# Altium schematic sheet [03] - MCU.SchDoc(MCU)
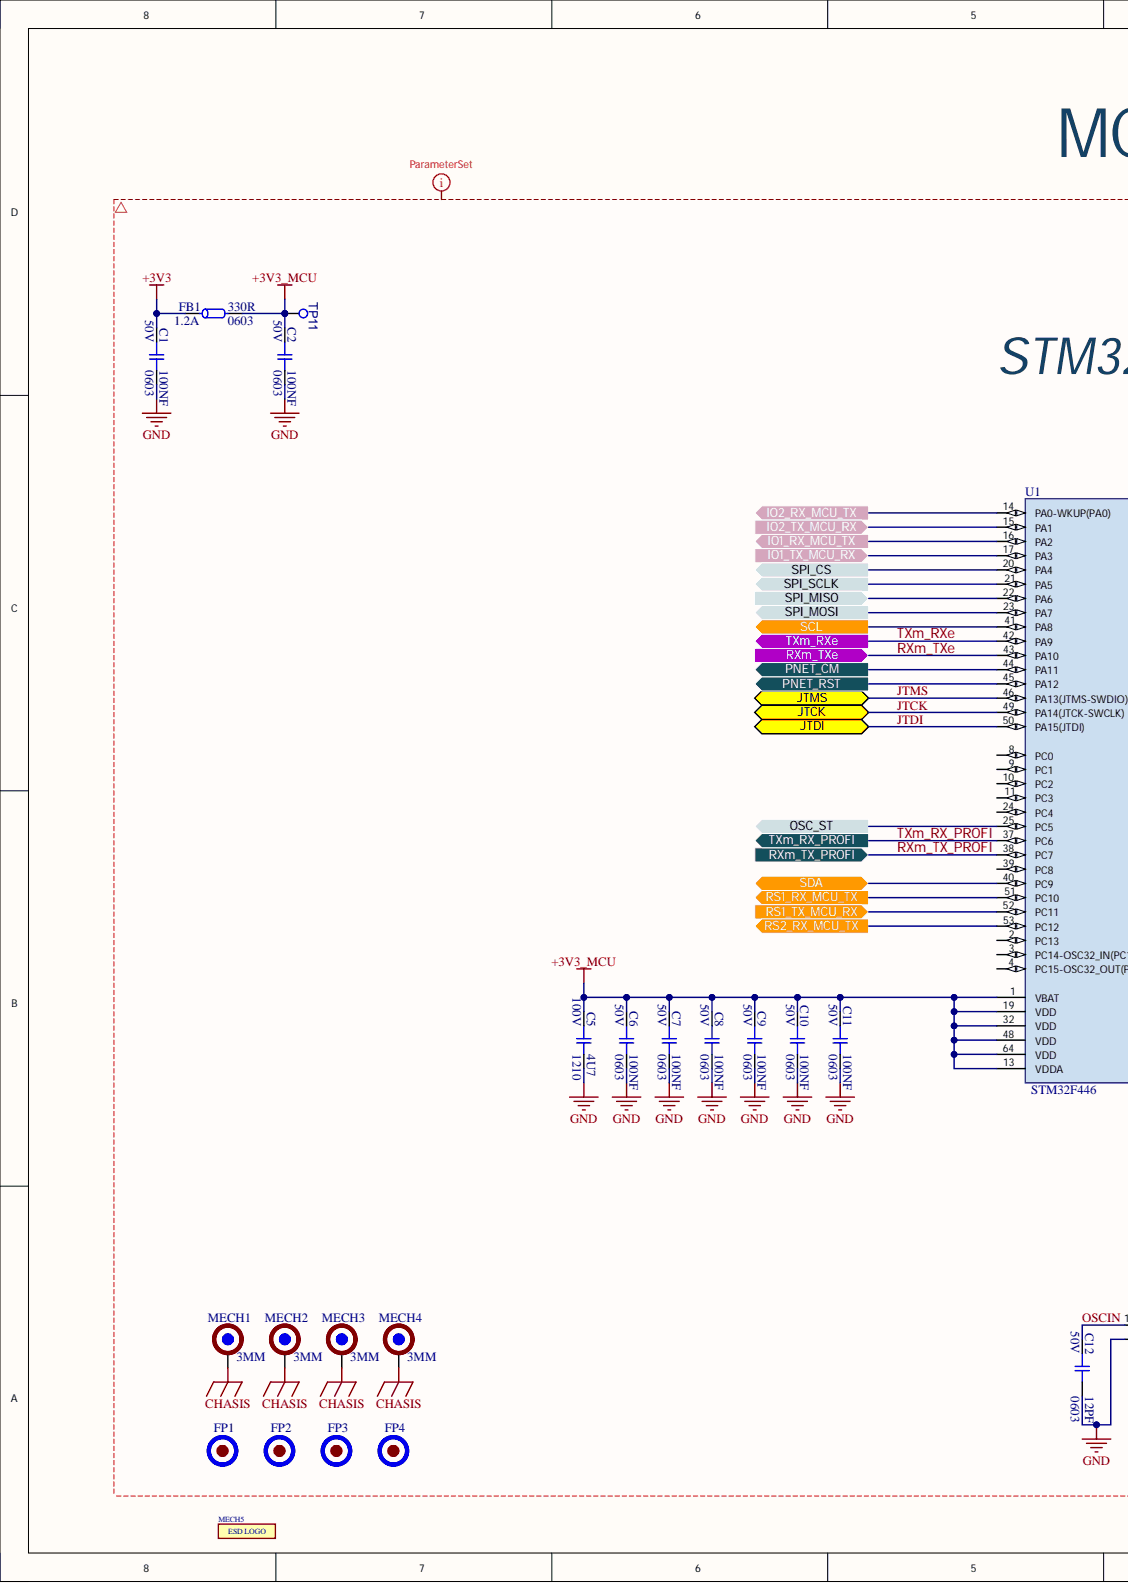
[diagram p0: [03] - MCU.SchDoc, left]
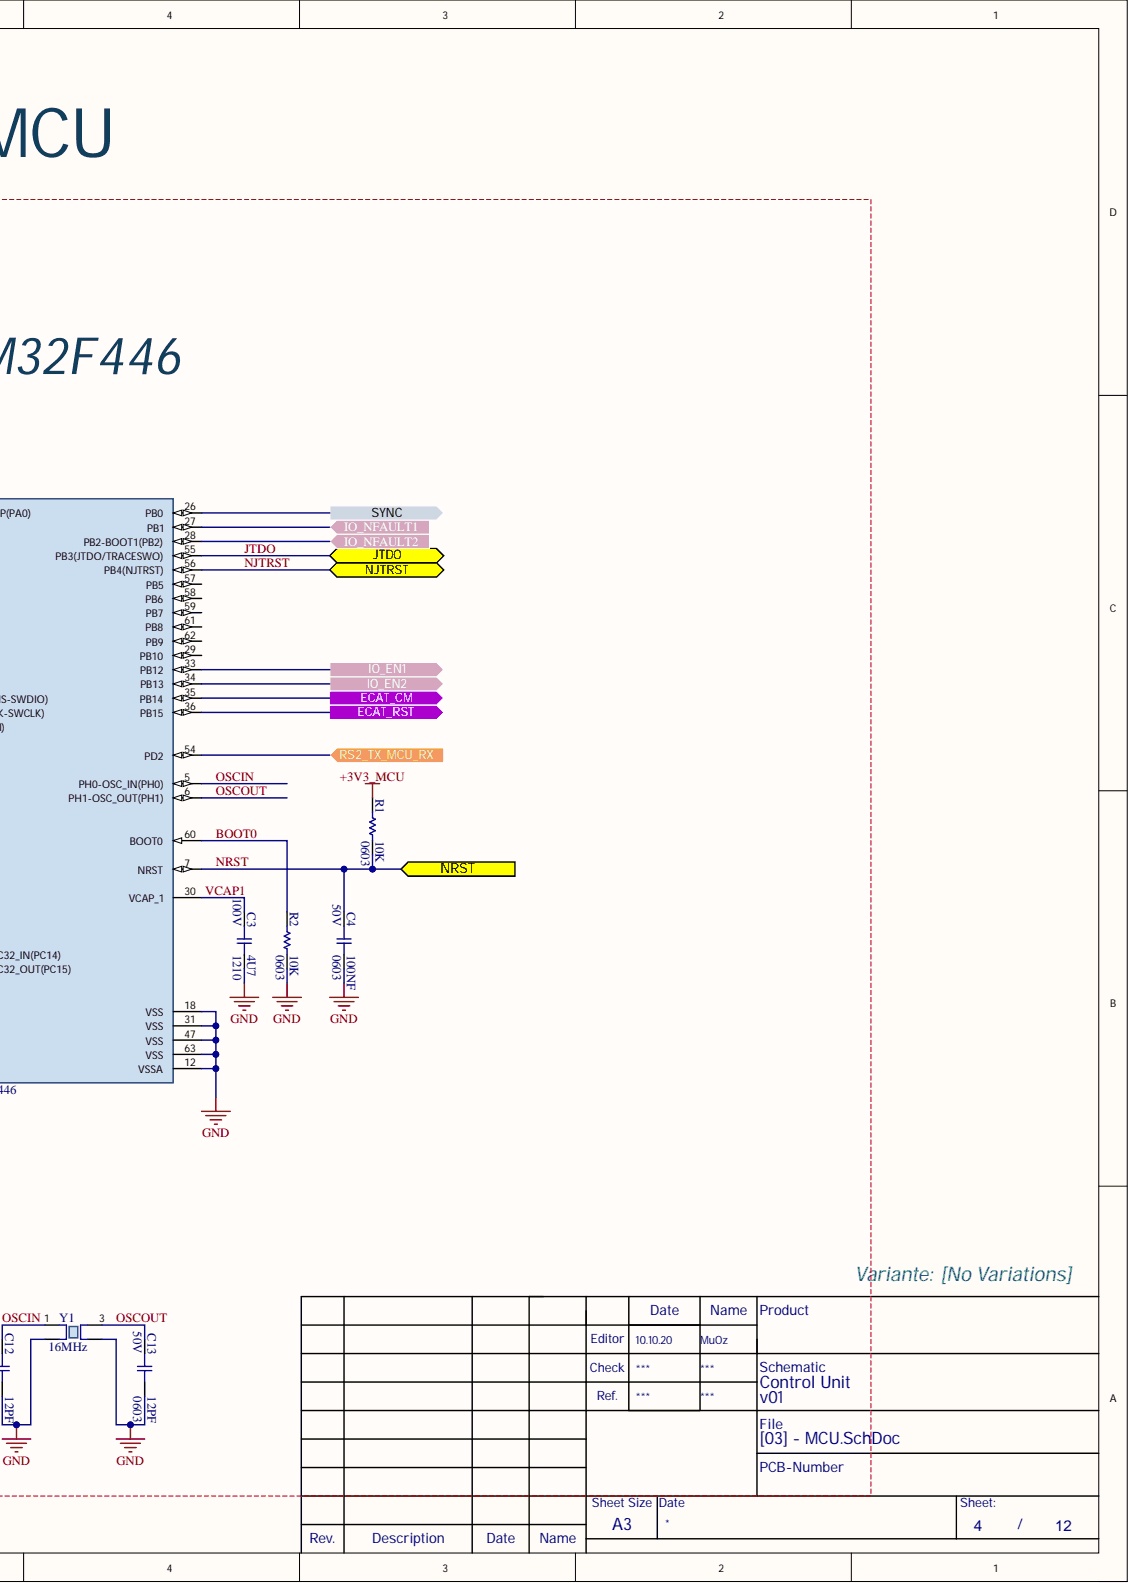
[diagram p1: [03] - MCU.SchDoc, right]

## Parts
C1  100NF  Case Code (Imperial): 0603  Voltage: 50V  [C-0603]
C2  100NF  Case Code (Imperial): 0603  Voltage: 50V  [C-0603]
C3  4U7  Case/Package: 1210  Voltage Rating: 100V  [C-1210]
C4  100NF  Case Code (Imperial): 0603  Voltage: 50V  [C-0603]
C5  4U7  Case/Package: 1210  Voltage Rating: 100V  [C-1210]
C6  100NF  Case Code (Imperial): 0603  Voltage: 50V  [C-0603]
C7  100NF  Case Code (Imperial): 0603  Voltage: 50V  [C-0603]
C8  100NF  Case Code (Imperial): 0603  Voltage: 50V  [C-0603]
C9  100NF  Case Code (Imperial): 0603  Voltage: 50V  [C-0603]
C10  100NF  Case Code (Imperial): 0603  Voltage: 50V  [C-0603]
C11  100NF  Case Code (Imperial): 0603  Voltage: 50V  [C-0603]
C12  12PF  Case Code (Imperial): 0603  Voltage: 50V  [C-0603]
C13  12PF  Case Code (Imperial): 0603  Voltage: 50V  [C-0603]
FB1  330R  Case Code (Imperial): 0603  Current Rating: 1.2A  [FB-0603]
FP1  MECH-Fiducial  [MECH-Fiducial]  (value not drawn)  (no BOM)
FP2  MECH-Fiducial  [MECH-Fiducial]  (value not drawn)  (no BOM)
FP3  MECH-Fiducial  [MECH-Fiducial]  (value not drawn)  (no BOM)
FP4  MECH-Fiducial  [MECH-Fiducial]  (value not drawn)  (no BOM)
MECH1  3MM  [MECH-3MM HOLE]  (no BOM)
MECH2  3MM  [MECH-3MM HOLE]  (no BOM)
MECH3  3MM  [MECH-3MM HOLE]  (no BOM)
MECH4  3MM  [MECH-3MM HOLE]  (no BOM)
MECH5  MECH-ESD-LOGO  [ESD_LOGO_4]  (value not drawn)  (no BOM)
R1  10K  Case Code (Imperial): 0603  [R-0603]
R2  10K  Case Code (Imperial): 0603  [R-0603]
TP11  MECH-TESTPOINT  [MECH-TESTPOINT]  (value not drawn)  (no BOM)
U1  STM32F446  [STM-LQFP64_M]
Y1  16MHz  [CRY-TSX-3225]

## Ports
ECAT_CM, ECAT_RST, IO1_RX_MCU_TX, IO1_TX_MCU_RX, IO2_RX_MCU_TX, IO2_TX_MCU_RX, IO_EN1, IO_EN2, IO_NFAULT1, IO_NFAULT2, JTCK, JTDI, JTDO, JTMS, NJTRST, NRST, OSC_ST, PNET_CM, PNET_RST, RS1_RX_MCU_TX, RS1_TX_MCU_RX, RS2_RX_MCU_TX, RS2_TX_MCU_RX, RXm_TX_PROFI, RXm_TXe, SCL, SDA, SPI_CS, SPI_MISO, SPI_MOSI, SPI_SCLK, SYNC, TXm_RX_PROFI, TXm_RXe

## Nets
+3V3: C1.1 FB1.1
+3V3_MCU: C2.1 C5.1 C6.1 C7.1 C8.1 C9.1 C10.1 C11.1 FB1.2 R1.1 TP11.1 U1.1(VBAT) U1.13(VDDA) U1.19(VDD) U1.32(VDD) U1.48(VDD) U1.64(VDD)
CHASIS: MECH1.1 MECH2.1 MECH3.1 MECH4.1
GND: C1.2 C2.2 C3.2 C4.2 C5.2 C6.2 C7.2 C8.2 C9.2 C10.2 C11.2 C12.2 C13.2 R2.2 U1.12(VSSA) U1.18(VSS) U1.31(VSS) U1.47(VSS) U1.63(VSS) Y1.2 Y1.4
BOOT0: R2.1 U1.60(BOOT0)
ECAT_CM: U1.35(PB14) ; port ECAT_CM
ECAT_RST: U1.36(PB15) ; port ECAT_RST
IO1_RX_MCU_TX: U1.16(PA2) ; port IO1_RX_MCU_TX
IO1_TX_MCU_RX: U1.17(PA3) ; port IO1_TX_MCU_RX
IO2_RX_MCU_TX: U1.14(PA0-WKUP(PA0)) ; port IO2_RX_MCU_TX
IO2_TX_MCU_RX: U1.15(PA1) ; port IO2_TX_MCU_RX
IO_EN1: U1.33(PB12) ; port IO_EN1
IO_EN2: U1.34(PB13) ; port IO_EN2
IO_NFAULT1: U1.27(PB1) ; port IO_NFAULT1
IO_NFAULT2: U1.28(PB2-BOOT1(PB2)) ; port IO_NFAULT2
JTCK: U1.49(PA14(JTCK-SWCLK)) ; port JTCK
JTDI: U1.50(PA15(JTDI)) ; port JTDI
JTDO: U1.55(PB3(JTDO/TRACESWO)) ; port JTDO
JTMS: U1.46(PA13(JTMS-SWDIO)) ; port JTMS
NJTRST: U1.56(PB4(NJTRST)) ; port NJTRST
NRST: C4.1 R1.2 U1.7(NRST) ; port NRST
OSC_ST: U1.25(PC5) ; port OSC_ST
OSCIN: C12.1 U1.5(PH0-OSC_IN(PH0)) Y1.1
OSCOUT: C13.1 U1.6(PH1-OSC_OUT(PH1)) Y1.3
PNET_CM: U1.44(PA11) ; port PNET_CM
PNET_RST: U1.45(PA12) ; port PNET_RST
RS1_RX_MCU_TX: U1.51(PC10) ; port RS1_RX_MCU_TX
RS1_TX_MCU_RX: U1.52(PC11) ; port RS1_TX_MCU_RX
RS2_RX_MCU_TX: U1.53(PC12) ; port RS2_RX_MCU_TX
RS2_TX_MCU_RX: U1.54(PD2) ; port RS2_TX_MCU_RX
RXm_TX_PROFI: U1.38(PC7) ; port RXm_TX_PROFI
RXm_TXe: U1.43(PA10) ; port RXm_TXe
SCL: U1.41(PA8) ; port SCL
SDA: U1.40(PC9) ; port SDA
SPI_CS: U1.20(PA4) ; port SPI_CS
SPI_MISO: U1.22(PA6) ; port SPI_MISO
SPI_MOSI: U1.23(PA7) ; port SPI_MOSI
SPI_SCLK: U1.21(PA5) ; port SPI_SCLK
SYNC: U1.26(PB0) ; port SYNC
TXm_RX_PROFI: U1.37(PC6) ; port TXm_RX_PROFI
TXm_RXe: U1.42(PA9) ; port TXm_RXe
VCAP1: C3.1 U1.30(VCAP_1)

Not connected: U1 2(PC13) 3(PC14-OSC32_IN(PC14)) 4(PC15-OSC32_OUT(PC15)) 8(PC0) 9(PC1) 10(PC2) 11(PC3) 24(PC4) 29(PB10) 39(PC8) 57(PB5) 58(PB6) 59(PB7) 61(PB8) 62(PB9)

## Library data of the parts
C1 C2 C4 C6-C11 = C-100NF-0603: CAP CER 0.1UF 50V X7R 0603; Manufacturer: TDK; Manufacturer Part Number: C1608X7R1H104K080AA; .Creator: F:TALI; .PCBCHK: USED; Dielectric Material: Ceramic; Temperature Coefficient: 30ppm/°C; Tolerance: 10%; Washability: OK; .SCHCHK: USED; Capacitance: 100nF; Case Code (Metric): 1608; Case/Package: 0603; Component Kind: Standard; ComponentLink1Description: http://www.mouser.com/ds/2/400/lcc_commercial_general_en-837201.pdf; ComponentLink1URL: http://www.mouser.com/ds/2/400/lcc_commercial_general_en-837201.pdf; ComponentLink2Description: https://product.tdk.com/info/en/catalog/spec/mlccspec_commercial_general_midvoltage_en.pdf; ComponentLink2URL: https://product.tdk.com/info/en/catalog/spec/mlccspec_commercial_general_midvoltage_en.pdf; ComponentLink3Description: http://uk.rs-online.com/webdocs/13d1/0900766b813d1103.pdf; ComponentLink3URL: http://uk.rs-online.com/webdocs/13d1/0900766b813d1103.pdf; ComponentLink4Description: http://www.tdk.co.jp/tefe02/e412_c.pdf; ComponentLink4URL: http://www.tdk.co.jp/tefe02/e412_c.pdf; ComponentLink5Description: http://www.farnell.com/datasheets/2291921.pdf; ComponentLink5URL: http://www.farnell.com/datasheets/2291921.pdf; ComponentLink6Description: http://www.farnell.com/datasheets/1744655.pdf; ComponentLink6URL: http://www.farnell.com/datasheets/1744655.pdf; ComponentLink7Description: http://catalogs.avx.com/SurfaceMount.pdf; ComponentLink7URL: http://catalogs.avx.com/SurfaceMount.pdf; ComponentLink8Description: http://www.farnell.com/datasheets/630895.pdf; ComponentLink8URL: http://www.farnell.com/datasheets/630895.pdf; ComponentLink9Description: http://www.avx.com/docs/Catalogs/cx7r.pdf; ComponentLink9URL: http://www.avx.com/docs/Catalogs/cx7r.pdf; ComponentLink10Description: http://www.avx.com/docs/catalogs/cauto.pdf; ComponentLink10URL: http://www.avx.com/docs/catalogs/cauto.pdf; ComponentLink11Description: https://www.verical.com/server-webapp/html/getDatasheet?partID=98691; ComponentLink11URL: https://www.verical.com/server-webapp/html/getDatasheet?partID=98691; ComponentLink12Description: https://www.avx.com/docs/Catalogs/flexiterm.pdf; ComponentLink12URL: https://www.avx.com/docs/Catalogs/flexiterm.pdf; Composition: Ceramic; Contact Plating: Matte,Tin; Depth: 0.8mm; Dielectric: X7R; Features: Soft Termination; Height: 0.8mm; Lead Free: Lead Free; Length: 1.6mm; Max Operating Temperature: 125°C; Min Operating Temperature: -55°C; Mount: Surface Mount; Number of Pins: 2; Package Quantity: 1; Packaging: Tape and Reel; Pricing 1: 1=0.1, 10=0.057, 100=0.0254, 500=0.01812, 1000=0.01423 (USD); Pricing 2: 1=0.23, 10=0.161, 100=0.0756, 500=0.05304, 1000=0.0439 (USD); Radiation Hardening: No; REACH SVHC: No SVHC; Stock 1: 0; Stock 2: 40000; Supplier 1: Digi-Key; Supplier 2: Digi-Key; Supplier Part Number 1: 445-1314-1-ND; Supplier Part Number 2: 478-3540-6-ND; Termination: SMD/SMT; Thickness: 0.037inch; Voltage Rating: 50V; Voltage Rating (DC): 50V; Weight: 7.1E-05oz; Width: 0.8mm; Library Name: BARKO_SCHLIB.SchLib
C3 C5 = C-4U7-1210-100V: CAP CER 4.7UF 100V X7S 1210; Manufacturer: Taiyo Yuden; Manufacturer Part Number: HMK325C7475KM-PE; .Creator: M.OZKAN; .PCBCHK: USED; Tolerance: 10%; Washability: OK; .SCHCHK: USED; Capacitance: 4.7uF; Component Kind: Standard; ComponentLink1Description: http://ds.yuden.co.jp/TYCOMPAS/ut/detail.do?productNo=HMK325C7475KM-PE&amp;fileName=HMK325C7475KM-PE_SS&amp;mode=specSheetDownload&src-supplier=Digi-Key; ComponentLink1URL: http://ds.yuden.co.jp/TYCOMPAS/ut/detail.do?productNo=HMK325C7475KM-PE&amp;fileName=HMK325C7475KM-PE_SS&amp;mode=specSheetDownload&src-supplier=Digi-Key; Max Operating Temperature: 125°C; Min Operating Temperature: -55°C; Mount: Surface Mount; Packaging: Tape and Reel; Pricing 1: 1=1.10000, 10=0.83900, 100=0.60030, 500=0.46474 (USD); RoHS: No; Stock 1: 0; Supplier 1: Digi-Key; Supplier Part Number 1: 587-HMK325C7475KM-PECT-ND; Library Name: BARKO_SCHLIB.SchLib
C12 C13 = C-12PF-0603: CAP CER 12PF 50V C0G/NP0 0603; Manufacturer: Samsung; Manufacturer Part Number: CL10C120JB8NNNC; .Creator: M.OZKAN; .PCBCHK: USED; Dielectric: C0G; Material: Ceramic; Temperature Coefficient: 300ppm/°C; Tolerance: 5%; Washability: OK; .SCHCHK: USED; Capacitance: 12pF; Case Code (Metric): 1608; Case/Package: 0603; Component Kind: Standard; ComponentLink1Description: https://media.digikey.com/pdf/Data%20Sheets/Samsung%20PDFs/CL_Series_MLCC_ds.pdf; ComponentLink1URL: https://media.digikey.com/pdf/Data%20Sheets/Samsung%20PDFs/CL_Series_MLCC_ds.pdf; ComponentLink2Description: https://www.verical.com/server-webapp/html/getDatasheet?partID=640658; ComponentLink2URL: https://www.verical.com/server-webapp/html/getDatasheet?partID=640658; ComponentLink3Description: https://produktinfo.conrad.com/datenblaetter/450000-474999/457317-da-01-en-VS_KONDENSATOR_0603.pdf; ComponentLink3URL: https://produktinfo.conrad.com/datenblaetter/450000-474999/457317-da-01-en-VS_KONDENSATOR_0603.pdf; Contact Plating: Tin; Depth: 0.8mm; Height: 0.8mm; Lead Free: Lead Free; Length: 1.6mm; Max Operating Temperature: 125°C; Min Operating Temperature: -55°C; Mount: Surface Mount; Package Quantity: 1; Packaging: Tape and Reel; Pricing 1: 1=0.1, 10=0.07, 100=0.0314, 500=0.0224, 1000=0.0176 (USD); Radiation Hardening: No; Stock 1: 259919; Supplier 1: Digi-Key; Supplier Part Number 1: 1276-1254-6-ND; Thickness: 0.035inch; Voltage Rating: 50V; Voltage Rating (DC): 50V; Width: 0.031inch; Library Name: BARKO_SCHLIB.SchLib
FB1 = FB-330R-0603: FERRITE BEAD 330 OHM 0603 1LN; Manufacturer: Murata; Manufacturer Part Number: BLM18PG331SN1D; .Creator: M.OZKAN; .PCBCHK: USED; DC Resistance (DCR): 150mR; Material: Steel,Ferrite; Number of Circuits: 1; Shielding: Unshielded; Test Frequency: 100MHz; Tolerance: 25%; Washability: OK; .SCHCHK: USED; Case Code (Metric): 1608; Case/Package: 0603; Component Kind: Standard; ComponentLink1Description: https://datasheet.ciiva.com/6211/enfa0003-6211710.pdf?src-supplier=Digi-Key; ComponentLink1URL: https://datasheet.ciiva.com/6211/enfa0003-6211710.pdf?src-supplier=Digi-Key; Core Material: Ferrite; DC Current: 1.2A; Depth: 0.95mm; Height: 0.8mm; Impedance: 330R; Lead Free: Lead Free; Length: 1.75mm; Max Current Rating: 1.2A; Max DC Current: 1.2A; Max Operating Temperature: 125°C; Min Operating Temperature: -55°C; Number of Lines: 1; Number of Pins: 2; Package Quantity: 1; Packaging: Tape and Reel; Pricing: 1=0.1, 10=0.076, 25=0.0684, 50=0.05, 100=0.0433, 250=0.0342, 500=0.0288, 1000=0.0243 (USD); Radiation Hardening: No; REACH SVHC: No SVHC; RoHS: Yes; Stock: 106218; Supplier 1: Digi-Key; Supplier Part Number 1: 490-5222-1-ND; Termination: SMD/SMT; Width: 0.8mm; Library Name: BARKO_SCHLIB.SchLib
FP1-FP4 = MECH-Fiducial: .Creator: F:TALI; .PCBCHK: USED; Washability: OK; .SCHCHK: USED; Library Name: BARKO_SCHLIB.SchLib
MECH1-MECH4 = MECH-3MM HOLE: .Creator: F:TALI; .PCBCHK: USED; Washability: OK; .SCHCHK: USED; Library Name: BARKO_SCHLIB.SchLib
MECH5 = MECH-ESD-LOGO: .Creator: F:TALI; .PCBCHK: USED; Washability: OK; .SCHCHK: USED; Library Name: BARKO_SCHLIB.SchLib
R1 R2 = R-10K-0603: RES SMD 10K OHM 1% 1/10W 0603; Manufacturer: Yageo; Manufacturer Part Number: RC0603FR-0710KL; .Creator: F:TALI; .PCBCHK: USED; Max Power Dissipation: 100mW; Resistance: 10kR; Temperature Coefficient: 100ppm/°C; Voltage Rating: 75V; Voltage Rating (DC): 50V; Washability: OK; .SCHCHK: USED; Case Code (Metric): 1608; Case/Package: 0603; Component Kind: Standard; ComponentLink1Description: http://www.farnell.com/datasheets/38590.pdf; ComponentLink1URL: http://www.farnell.com/datasheets/38590.pdf; ComponentLink2Description: https://www.verical.com/server-webapp/html/getDatasheet?partID=409289; ComponentLink2URL: https://www.verical.com/server-webapp/html/getDatasheet?partID=409289; ComponentLink3Description: http://www.farnell.com/datasheets/1868787.pdf; ComponentLink3URL: http://www.farnell.com/datasheets/1868787.pdf; ComponentLink4Description: http://www.farnell.com/datasheets/443971.pdf; ComponentLink4URL: http://www.farnell.com/datasheets/443971.pdf; ComponentLink5Description: http://www.farnell.com/datasheets/1738695.pdf; ComponentLink5URL: http://www.farnell.com/datasheets/1738695.pdf; ComponentLink6Description: http://www.yageo.com/documents/recent/PYu-RC_Group_51_RoHS_L_9.pdf; ComponentLink6URL: http://www.yageo.com/documents/recent/PYu-RC_Group_51_RoHS_L_9.pdf; ComponentLink7Description: https://www.verical.com/server-webapp/html/getDatasheet?partID=327958; ComponentLink7URL: https://www.verical.com/server-webapp/html/getDatasheet?partID=327958; ComponentLink8Description: http://www.yageo.com/documents/recent/PYu-RC_Group_51_RoHS_L_2.pdf; ComponentLink8URL: http://www.yageo.com/documents/recent/PYu-RC_Group_51_RoHS_L_2.pdf; ComponentLink9Description: http://www.farnell.com/datasheets/1678796.pdf; ComponentLink9URL: http://www.farnell.com/datasheets/1678796.pdf; ComponentLink10Description: http://industrial.panasonic.com/www-cgi/jvcr13pz.cgi?E+PZ+3+AOA0002+ERJ1GNJ120C+7+WW; ComponentLink10URL: http://industrial.panasonic.com/www-cgi/jvcr13pz.cgi?E+PZ+3+AOA0002+ERJ1GNJ120C+7+WW; ComponentLink11Description: http://industrial.panasonic.com/www-data/pdf/AOA0000/AOA0000CE1.pdf; ComponentLink11URL: http://industrial.panasonic.com/www-data/pdf/AOA0000/AOA0000CE1.pdf; ComponentLink12Description: http://www.jameco.com/Jameco/Products/ProdDS/2007265.pdf; ComponentLink12URL: http://www.jameco.com/Jameco/Products/ProdDS/2007265.pdf; ComponentLink13Description: https://industrial.panasonic.com/cdbs/www-data/pdf/RDA0000/AOA0000C301.pdf; ComponentLink13URL: https://industrial.panasonic.com/cdbs/www-data/pdf/RDA0000/AOA0000C301.pdf; Composition: Thick Film; Contact Plating: Matte,Tin; Depth: 0.8mm; Features: Automotive AEC-Q200; Height: 0.45mm; Lead Free: Lead Free; Lead Pitch: 0.3mm; Length: 1.6mm; Max Operating Temperature: 155°C; Military Standard: Not; Min Operating Temperature: -55°C; Mount: Surface Mount; Number of Pins: 2; Number of Terminations: 2; Package Quantity: 1; Packaging: Tape and Reel; Power Rating: 100mW; Pricing 1: 1=0.1, 10=0.026, 100=0.0107, 1000=0.00478, 2500=0.00415 (USD); Pricing 2: 1=0.1, 10=0.022, 100=0.0088, 1000=0.00396, 2500=0.00344 (USD); Pricing 3: 1=0.1, 10=0.044, 100=0.0178, 1000=0.00798, 2500=0.00693 (USD); Radiation Hardening: No; REACH SVHC: No SVHC; Stock 1: 37479107; Stock 2: 34952003; Stock 3: 0; Supplier 1: Digi-Key; Supplier 2: Digi-Key; Supplier 3: Digi-Key; Supplier Part Number 1: 311-10.0KHRCT-ND; Supplier Part Number 2: 311-10KGRDKR-ND; Supplier Part Number 3: YAG1290DKR-ND; Termination: SMD/SMT; Tolerance: 1%; Weight: 7.1E-05oz; Width: 0.8mm; Working Voltage: 75V; Library Name: BARKO_SCHLIB.SchLib
TP11 = MECH-TESTPOINT: .Creator: F:TALI; .PCBCHK: USED; Washability: OK; .SCHCHK: USED; Library Name: BARKO_SCHLIB.SchLib
U1 = IC-STM32F446RCT6TR: IC MCU 32BIT 256KB FLASH 64LQFP; Manufacturer: STMicroelectronics; Core Architecture: ARM; Frequency: 180MHz; Interface: I2C,SPI,USB,IrDA,SD,UART,USART,EBI/EMI; Number of I/Os: 50; Oscillator Type: Internal; Peripherals: Brown-out Detect/Reset,POR,PWM,WDT,DMA,LVD; Case/Package: LQFP; Component Kind: Standard; ComponentLink1Description: http://www.st.com/content/ccc/resource/technical/document/errata_sheet/c3/6b/f8/32/fc/01/48/6e/DM00155929.pdf/files/DM00155929.pdf/jcr:content/translations/en.DM00155929.pdf?src-supplier=Digi-Key; ComponentLink1URL: http://www.st.com/content/ccc/resource/technical/document/errata_sheet/c3/6b/f8/32/fc/01/48/6e/DM00155929.pdf/files/DM00155929.pdf/jcr:content/translations/en.DM00155929.pdf?src-supplier=Digi-Key; Manufacturer Part Number: STM32F446RCT6TR; Max Operating Temperature: 85°C; Memory Size: 256KB; Memory Type: FLASH; Min Operating Temperature: -40°C; Packaging: Tape and Reel; Pricing: 1=6.76, 10=6.11, 25=5.826, 100=5.0588, 250=4.8314, 500=4.4051 (USD); RoHS: No; Stock: 739; Supplier 1: Digi-Key; Supplier Part Number 1: 497-19357-1-ND; Library Name: BARKO_SCHLIB.SchLib
Y1 = CRYSTAL-TSX-3225 16.0000MF18X-AC0: CRYSTAL 16.00 MHZ 9PF SMD; Manufacturer: Epson; ESR (Equivalent Series Resistance): 60R; Frequency Stability: 18ppm; Frequency Tolerance: 10ppm; Case/Package: SMD/SMT; Component Kind: Standard; ComponentLink1Description: https://www.eea.epson.com/wp-content/uploads/2016/11/November-2016-PCS-MASTER-BOOK.pdf?src-supplier=Verical; ComponentLink1URL: https://www.eea.epson.com/wp-content/uploads/2016/11/November-2016-PCS-MASTER-BOOK.pdf?src-supplier=Verical; ComponentLink2Description: https://www5.epsondevice.com/en/information/support/pdf/june_2018_psc_masterbook.pdf?src-supplier=Digi-Key; ComponentLink2URL: https://www5.epsondevice.com/en/information/support/pdf/june_2018_psc_masterbook.pdf?src-supplier=Digi-Key; ComponentLink3Description: https://www.eea.epson.com/wp-content/uploads/2016/11/November-2016-PCS-MASTER-BOOK.pdf?src-supplier=Mouser; ComponentLink3URL: https://www.eea.epson.com/wp-content/uploads/2016/11/November-2016-PCS-MASTER-BOOK.pdf?src-supplier=Mouser; ComponentLink4Description: https://www.eea.epson.com/wp-content/uploads/2016/11/November-2016-PCS-MASTER-BOOK.pdf?src-supplier=Dove; ComponentLink4URL: https://www.eea.epson.com/wp-content/uploads/2016/11/November-2016-PCS-MASTER-BOOK.pdf?src-supplier=Dove; Frequency: 16MHz; Height: 0.024inch; Length: 0.126inch; Library Name: SCHLIB.SchLib; Load Capacitance: 9pF; Manufacturer Part Number: TSX-3225 16.0000MF18X-AC0; Max Operating Temperature: 75°C; Min Operating Temperature: -20°C; Mount: Surface Mount; Packaging: Tape and Reel; Pricing: 1=0.33, 10=0.275, 50=0.2476, 100=0.22, 500=0.209 (USD); RoHS: Yes; Stock: 467; Supplier 1: Digi-Key; Supplier Part Number 1: SER4070CT-ND; Width: 0.098inch
